# Altium schematic sheet ATMega2560 and USB.SchDoc
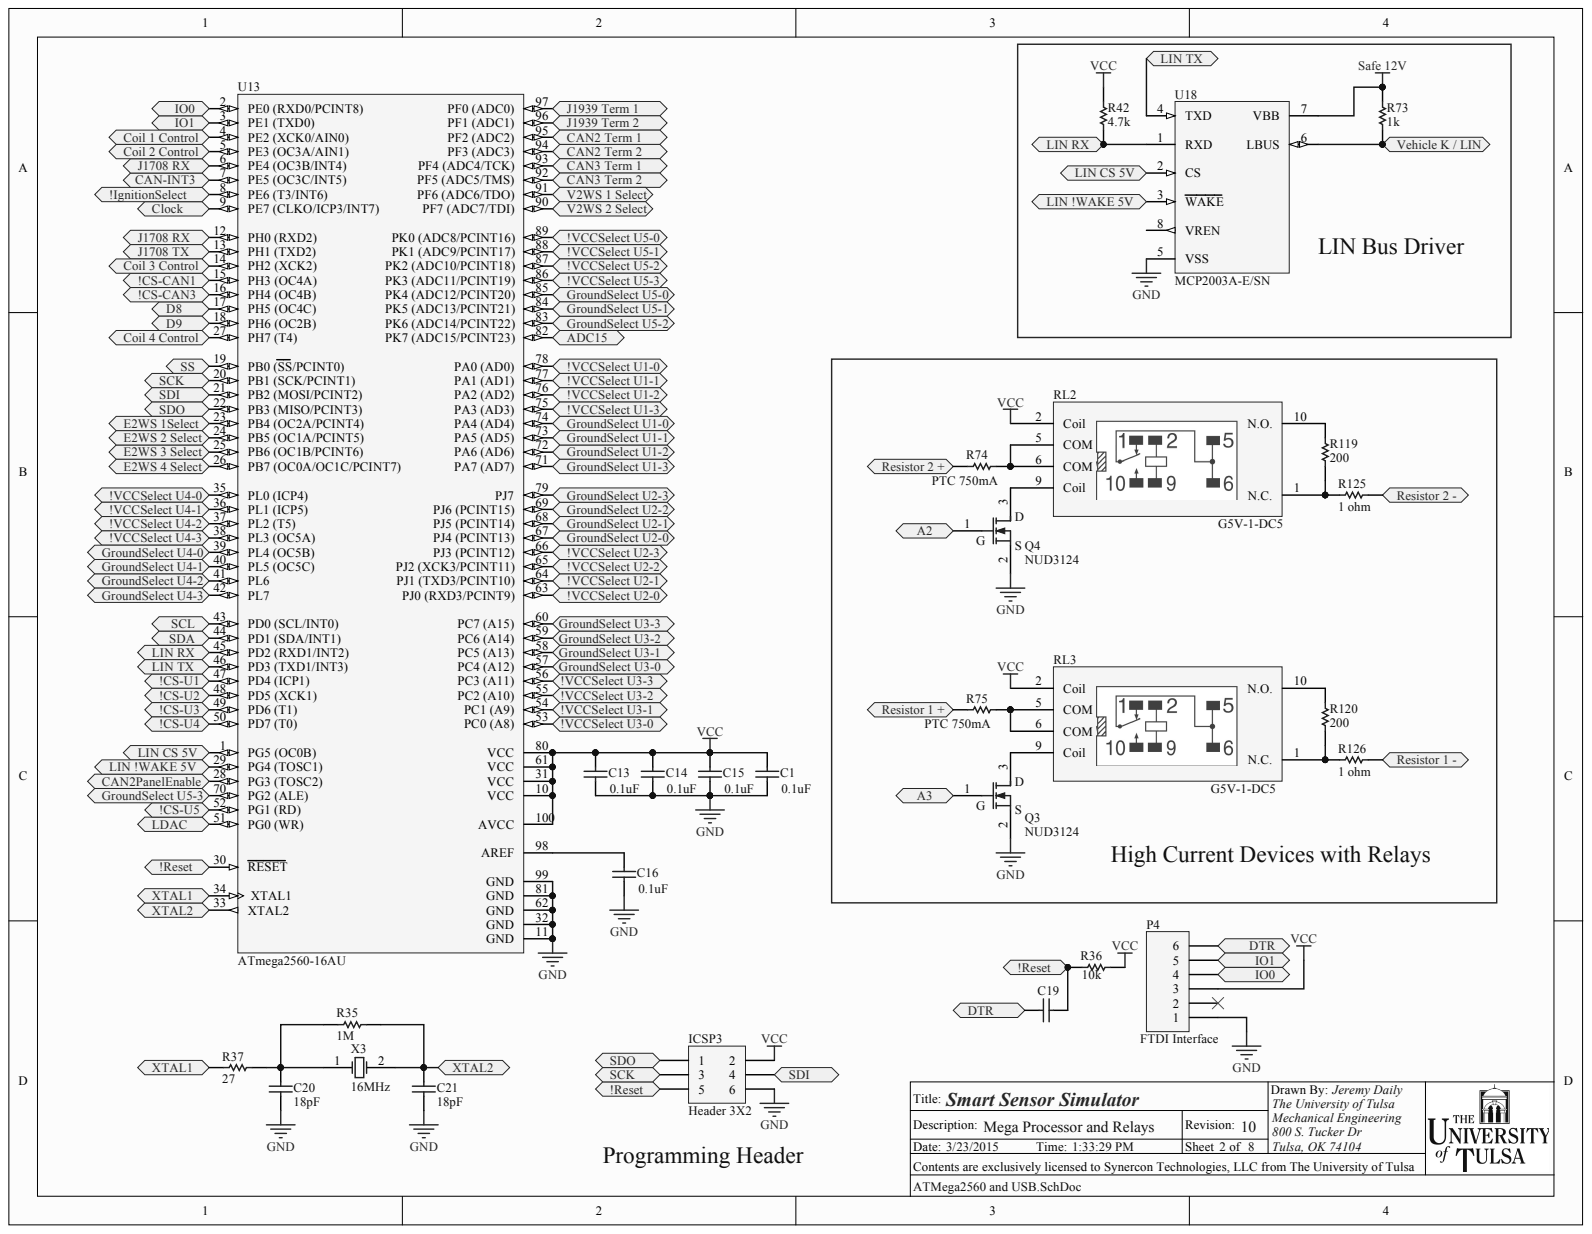
[diagram p0: ATMega2560 and USB.SchDoc]

## Parts
C1  0.1uF  [0603]
C13  0.1uF  [0603]
C14  0.1uF  [0603]
C15  0.1uF  [0603]
C16  0.1uF  [0603]
C19  0.1uF  [0603]  (value not drawn)
C20  18pF  [0603]
C21  18pF  [0603]
ICSP3  Header 3X2  [ICSP Header (2x3)]
P4  FTDI Interface  [FTDi Header]
Q3  NUD3124  [SOT-23-3]
Q4  NUD3124  [SOT-23-3]
R35  1M  [0603]
R36  10k  [0805]
R37  27  [0805]
R42  4.7k  [0603]
R73  1k  [0603]
R74  PTC 750mA  [1206]
R75  PTC 750mA  [1206]
R119  200  [0805]
R120  200  [0805]
R125  1 ohm  [NTC 7.5mm]
R126  1 ohm  [NTC 7.5mm]
RL2  G5V-1-DC5  [OMRON G5V Relay]
RL3  G5V-1-DC5  [OMRON G5V Relay]
U13  ATmega2560-16AU  [100A_L]
U18  MCP2003A-E/SN  [SOIC-SN8_N]
X3  16MHz  [XTAL 2 pad]

## Ports
!CS-CAN1, !CS-CAN3, !CS-U1, !CS-U2, !CS-U3, !CS-U4, !CS-U5, !IGNITIONSELECT, !RESET, !VCCSELECT U1-0, !VCCSELECT U1-1, !VCCSELECT U1-2, !VCCSELECT U1-3, !VCCSELECT U2-0, !VCCSELECT U2-1, !VCCSELECT U2-2, !VCCSELECT U2-3, !VCCSELECT U3-0, !VCCSELECT U3-1, !VCCSELECT U3-2, !VCCSELECT U3-3, !VCCSELECT U4-0, !VCCSELECT U4-1, !VCCSELECT U4-2, !VCCSELECT U4-3, !VCCSELECT U5-0, !VCCSELECT U5-1, !VCCSELECT U5-2, !VCCSELECT U5-3, A2, A3, ADC15, CAN2 TERM 1, CAN2 TERM 2, CAN2PANELENABLE, CAN3 TERM 1, CAN3 TERM 2, CAN-INT3, CLOCK, COIL 1 CONTROL, COIL 2 CONTROL, COIL 3 CONTROL, COIL 4 CONTROL, D8, D9, DTR, E2WS 1SELECT, E2WS 2 SELECT, E2WS 3 SELECT, E2WS 4 SELECT, GROUNDSELECT U1-0, GROUNDSELECT U1-1, GROUNDSELECT U1-2, GROUNDSELECT U1-3, GROUNDSELECT U2-0, GROUNDSELECT U2-1, GROUNDSELECT U2-2, GROUNDSELECT U2-3, GROUNDSELECT U3-0, GROUNDSELECT U3-1, GROUNDSELECT U3-2, GROUNDSELECT U3-3, GROUNDSELECT U4-0, GROUNDSELECT U4-1, GROUNDSELECT U4-2, GROUNDSELECT U4-3, GROUNDSELECT U5-0, GROUNDSELECT U5-1, GROUNDSELECT U5-2, GROUNDSELECT U5-3, IO0, IO1, J1708 RX, J1708 TX, J1939 TERM 1, J1939 TERM 2, LDAC, LIN !WAKE 5V, LIN CS 5V, LIN RX, LIN TX, RESISTOR 1 +, RESISTOR 1 -, RESISTOR 2 +, RESISTOR 2 -, SCK, SCL, SDA, SDI, SDO, SS, V2WS 1 SELECT, V2WS 2 SELECT, VEHICLE K / LIN, XTAL1, XTAL2

## Nets
GND: C1.2 C13.2 C14.2 C15.2 C16.2 C20.1 C21.1 ICSP3.6 P4.1 Q3.2 Q4.2 U13.11(GND) U13.32(GND) U13.62(GND) U13.81(GND) U13.99(GND) U18.5(VSS)
SAFE 12V: R73.1 U18.7(VBB)
VCC: C1.1 C13.1 C14.1 C15.1 ICSP3.2 P4.3 R36.1 R42.2 RL2.2(Coil) RL3.2(Coil) U13.10(VCC) U13.31(VCC) U13.61(VCC) U13.80(VCC) U13.100(AVCC)
!CS-CAN1: U13.15(PH3_(OC4A)) ; port !CS-CAN1
!CS-CAN3: U13.16(PH4_(OC4B)) ; port !CS-CAN3
!CS-U1: U13.47(PD4_(ICP1)) ; port !CS-U1
!CS-U2: U13.48(PD5_(XCK1)) ; port !CS-U2
!CS-U3: U13.49(PD6_(T1)) ; port !CS-U3
!CS-U4: U13.50(PD7_(T0)) ; port !CS-U4
!CS-U5: U13.52(PG1_(RD)) ; port !CS-U5
A2: Q4.1 ; port A2
A3: Q3.1 ; port A3
ADC15: U13.82(PK7_(ADC15/PCINT23)) ; port ADC15
CAN-INT3: U13.7(PE5_(OC3C/INT5)) ; port CAN-INT3
D8: U13.17(PH5_(OC4C)) ; port D8
D9: U13.18(PH6_(OC2B)) ; port D9
DTR: C19.2 P4.6 ; port DTR
IO0: P4.4 U13.2(PE0_(RXD0/PCINT8)) ; port IO0
IO1: P4.5 U13.3(PE1_(TXD0)) ; port IO1
J1708 RX: U13.6(PE4_(OC3B/INT4)) U13.12(PH0_(RXD2)) ; port J1708 RX
J1708 TX: U13.13(PH1_(TXD2)) ; port J1708 TX
LDAC: U13.51(PG0_(WR)) ; port LDAC
LIN !WAKE 5V: U13.29(PG4_(TOSC1)) U18.3(WAKE) ; port LIN !WAKE 5V
LIN CS 5V: U13.1(PG5_(OC0B)) U18.2(CS) ; port LIN CS 5V
LIN RX: R42.1 U13.45(PD2_(RXD1/INT2)) U18.1(RXD) ; port LIN RX
LIN TX: U13.46(PD3_(TXD1/INT3)) U18.4(TXD) ; port LIN TX
SCK: ICSP3.3 U13.20(PB1_(SCK/PCINT1)) ; port SCK
SCL: U13.43(PD0_(SCL/INT0)) ; port SCL
SDA: U13.44(PD1_(SDA/INT1)) ; port SDA
SDI: ICSP3.4 U13.21(PB2_(MOSI/PCINT2)) ; port SDI
SDO: ICSP3.1 U13.22(PB3_(MISO/PCINT3)) ; port SDO
SS: U13.19(PB0_(SS/PCINT0)) ; port SS
XTAL1: R37.2 U13.34(XTAL1) ; port XTAL1
XTAL2: C21.2 R35.1 U13.33(XTAL2) X3.2 ; port XTAL2
NetC16_1: C16.1 U13.98(AREF)
NetC19_1: C19.1 ICSP3.5 R36.2 U13.30(RESET) ; port !RESET
NetC20_2: C20.2 R35.2 R37.1 X3.1
NetQ3_3: Q3.3 RL3.9(Coil)
NetQ4_3: Q4.3 RL2.9(Coil)
NetR73_2: R73.2 U18.6(LBUS) ; port VEHICLE K / LIN
NetR74_1: R74.1
NetR74_2: R74.2 RL2.5(COM) RL2.6(COM)
NetR75_1: R75.1
NetR75_2: R75.2 RL3.5(COM) RL3.6(COM)
NetR119_1: R119.1 RL2.10(N.O.)
NetR119_2: R119.2 R125.1 RL2.1(N.C.)
NetR120_1: R120.1 RL3.10(N.O.)
NetR120_2: R120.2 R126.1 RL3.1(N.C.)
NetR125_2: R125.2
NetR126_2: R126.2
NetU13_4: U13.4(PE2_(XCK0/AIN0)) ; port COIL 1 CONTROL
NetU13_5: U13.5(PE3_(OC3A/AIN1)) ; port COIL 2 CONTROL
NetU13_8: U13.8(PE6_(T3/INT6)) ; port !IGNITIONSELECT
NetU13_9: U13.9(PE7_(CLKO/ICP3/INT7)) ; port CLOCK
NetU13_14: U13.14(PH2_(XCK2)) ; port COIL 3 CONTROL
NetU13_23: U13.23(PB4_(OC2A/PCINT4)) ; port E2WS 1SELECT
NetU13_24: U13.24(PB5_(OC1A/PCINT5)) ; port E2WS 2 SELECT
NetU13_25: U13.25(PB6_(OC1B/PCINT6)) ; port E2WS 3 SELECT
NetU13_26: U13.26(PB7_(OC0A/OC1C/PCINT7)) ; port E2WS 4 SELECT
NetU13_27: U13.27(PH7_(T4)) ; port COIL 4 CONTROL
NetU13_28: U13.28(PG3_(TOSC2)) ; port CAN2PANELENABLE
NetU13_35: U13.35(PL0_(ICP4)) ; port !VCCSELECT U4-0
NetU13_36: U13.36(PL1_(ICP5)) ; port !VCCSELECT U4-1
NetU13_37: U13.37(PL2_(T5)) ; port !VCCSELECT U4-2
NetU13_38: U13.38(PL3_(OC5A)) ; port !VCCSELECT U4-3
NetU13_39: U13.39(PL4_(OC5B)) ; port GROUNDSELECT U4-0
NetU13_40: U13.40(PL5_(OC5C)) ; port GROUNDSELECT U4-1
NetU13_41: U13.41(PL6) ; port GROUNDSELECT U4-2
NetU13_42: U13.42(PL7) ; port GROUNDSELECT U4-3
NetU13_53: U13.53(PC0_(A8)) ; port !VCCSELECT U3-0
NetU13_54: U13.54(PC1_(A9)) ; port !VCCSELECT U3-1
NetU13_55: U13.55(PC2_(A10)) ; port !VCCSELECT U3-2
NetU13_56: U13.56(PC3_(A11)) ; port !VCCSELECT U3-3
NetU13_57: U13.57(PC4_(A12)) ; port GROUNDSELECT U3-0
NetU13_58: U13.58(PC5_(A13)) ; port GROUNDSELECT U3-1
NetU13_59: U13.59(PC6_(A14)) ; port GROUNDSELECT U3-2
NetU13_60: U13.60(PC7_(A15)) ; port GROUNDSELECT U3-3
NetU13_63: U13.63(PJ0_(RXD3/PCINT9)) ; port !VCCSELECT U2-0
NetU13_64: U13.64(PJ1_(TXD3/PCINT10)) ; port !VCCSELECT U2-1
NetU13_65: U13.65(PJ2_(XCK3/PCINT11)) ; port !VCCSELECT U2-2
NetU13_66: U13.66(PJ3_(PCINT12)) ; port !VCCSELECT U2-3
NetU13_67: U13.67(PJ4_(PCINT13)) ; port GROUNDSELECT U2-0
NetU13_68: U13.68(PJ5_(PCINT14)) ; port GROUNDSELECT U2-1
NetU13_69: U13.69(PJ6_(PCINT15)) ; port GROUNDSELECT U2-2
NetU13_70: U13.70(PG2_(ALE)) ; port GROUNDSELECT U5-3
NetU13_71: U13.71(PA7_(AD7)) ; port GROUNDSELECT U1-3
NetU13_72: U13.72(PA6_(AD6)) ; port GROUNDSELECT U1-2
NetU13_73: U13.73(PA5_(AD5)) ; port GROUNDSELECT U1-1
NetU13_74: U13.74(PA4_(AD4)) ; port GROUNDSELECT U1-0
NetU13_75: U13.75(PA3_(AD3)) ; port !VCCSELECT U1-3
NetU13_76: U13.76(PA2_(AD2)) ; port !VCCSELECT U1-2
NetU13_77: U13.77(PA1_(AD1)) ; port !VCCSELECT U1-1
NetU13_78: U13.78(PA0_(AD0)) ; port !VCCSELECT U1-0
NetU13_79: U13.79(PJ7) ; port GROUNDSELECT U2-3
NetU13_83: U13.83(PK6_(ADC14/PCINT22)) ; port GROUNDSELECT U5-2
NetU13_84: U13.84(PK5_(ADC13/PCINT21)) ; port GROUNDSELECT U5-1
NetU13_85: U13.85(PK4_(ADC12/PCINT20)) ; port GROUNDSELECT U5-0
NetU13_86: U13.86(PK3_(ADC11/PCINT19)) ; port !VCCSELECT U5-3
NetU13_87: U13.87(PK2_(ADC10/PCINT18)) ; port !VCCSELECT U5-2
NetU13_88: U13.88(PK1_(ADC9/PCINT17)) ; port !VCCSELECT U5-1
NetU13_89: U13.89(PK0_(ADC8/PCINT16)) ; port !VCCSELECT U5-0
NetU13_90: U13.90(PF7_(ADC7/TDI)) ; port V2WS 2 SELECT
NetU13_91: U13.91(PF6_(ADC6/TDO)) ; port V2WS 1 SELECT
NetU13_92: U13.92(PF5_(ADC5/TMS)) ; port CAN3 TERM 2
NetU13_93: U13.93(PF4_(ADC4/TCK)) ; port CAN3 TERM 1
NetU13_94: U13.94(PF3_(ADC3)) ; port CAN2 TERM 2
NetU13_95: U13.95(PF2_(ADC2)) ; port CAN2 TERM 1
NetU13_96: U13.96(PF1_(ADC1)) ; port J1939 TERM 2
NetU13_97: U13.97(PF0_(ADC0)) ; port J1939 TERM 1

Not connected: P4 2; U18 8(VREN)

## Library data of the parts
C1 C13-C16 C19 = Cap: CAP CER 0.1UF 50V 10% X7R 0603; Manufacturer: Murata Electronics North America; PartNumber: GRM188R71H104KA93D; Component Kind: Standard; Library Name: SSS Schematic Library.SchLib; Signal Integrity: Cap; Simulation: CAP; Supplier 1: Digi-Key; Supplier Part Number 1: 490-1519-1-ND
C20 C21 = Cap: CAP CER 18PF 50V 5% NP0 0603; Manufacturer: Kemet; PartNumber: C0603C180J5GACTU; Component Kind: Standard; Library Name: SSS Schematic Library.SchLib; Signal Integrity: Cap; Simulation: CAP; Supplier 1: Digi-Key; Supplier Part Number 1: 399-1052-1-ND
ICSP3 = Header 3X2: CONN HEADER 6POS DUAL .100 TIN; Manufacturer: 3M; PartNumber: 929836-01-03-RK; Component Kind: Standard; Library Name: Miscellaneous Connectors.IntLib; Signal Integrity: Connector; Supplier 1: Digi-Key; Supplier Part Number 1: 929836E-01-03-ND
P4 = Header 6: Header, 6-Pin; Component Kind: Standard; Library Name: Miscellaneous Connectors.IntLib; Signal Integrity: Connector
Q3 Q4 = IRLML6344TRPbF: IC INDCT LOAD DRVR AUTO SOT23; Manufacturer: ON Semiconductor; PartNumber: NUD3124LT1G; Component Kind: Standard; Library Name: SSS Schematic Library.SchLib; Supplier 1: Digi-Key; Supplier Part Number 1: NUD3124LT1GOSCT-ND
R35 = Chip Resistor: RES SMD 1M OHM 1% 1/10W 0603; Manufacturer: Panasonic Electronic Components; PartNumber: ERJ-3EKF1004V; Component Kind: Standard; Library Name: SSS Schematic Library.SchLib; Supplier 1: Digi-Key; Supplier Part Number 1: P1.00MHCT-ND
R36 = Chip Resistor: RES SMD 10K OHM 1% 1/8W 0805; Manufacturer: Panasonic Electronic Components; PartNumber: ERJ-6ENF1002V; Component Kind: Standard; Library Name: SSS Schematic Library.SchLib; Supplier 1: Digi-Key; Supplier Part Number 1: P10.0KCCT-ND
R37 = Chip Resistor: RES SMD 27 OHM 1% 1/8W 0805; Manufacturer: Panasonic Electronic Components; PartNumber: ERJ-6ENF27R0V; Component Kind: Standard; Library Name: SSS Schematic Library.SchLib; Supplier 1: Digi-Key; Supplier Part Number 1: P27.0CCT-ND
R42 = Chip Resistor: RES SMD 4.7K OHM 1% 1/8W 0805; Manufacturer: Panasonic Electronic Components; PartNumber: ERJ-6ENF4701V; Component Kind: Standard; Supplier 1: Digi-Key; Supplier Part Number 1: P4.70KCCT-ND; Library Name: Daily_Integrated_Library.IntLib
R73 = Chip Resistor: RES SMD 1K OHM 1% 1/10W 0603; Manufacturer: Panasonic Electronic Components; PartNumber: ERJ-3EKF1001V; Component Kind: Standard; Library Name: SSS Schematic Library.SchLib; Supplier 1: Digi-Key; Supplier Part Number 1: P1.00KHCT-ND
R74 R75 = SMD Resistor: PTC RESTTBLE 0.75A 16V CHIP 1206; Manufacturer: Bel Fuse Inc; PartNumber: 0ZCJ0075AF2E; Component Kind: Standard; Library Name: SSS Schematic Library.SchLib; Supplier 1: Digi-Key; Supplier Part Number 1: 507-1805-1-ND
R119 R120 = Chip Resistor: RES SMD 200 OHM 1% 1/2W 0805; Manufacturer: Panasonic Electronic Components; PartNumber: ERJ-P06F2000V; Component Kind: Standard; Library Name: SSS Schematic Library.SchLib; Supplier 1: Digi-Key; Supplier Part Number 1: P16064CT-ND
R125 R126 = Chip Resistor: RES 1 OHM 5W 10% AXIAL; Manufacturer: Yageo; PartNumber: PNP300JR-73-1R; Component Kind: Standard; Library Name: SSS Schematic Library.SchLib; Supplier 1: Digi-Key; Supplier Part Number 1: 1.0AECT-ND
RL2 RL3 = Relay-G5V: OMRON RELAY GENERAL PURPOSE SPDT 1A 5V; Manufacturer: Omron Electronics Inc-EMC Div; Component Kind: Standard; Library Name: SSS Schematic Library.SchLib; PartNumber: G5V-1-DC5; Supplier 1: Digi-Key; Supplier Part Number 1: Z773-ND
U13 = ATmega2560-16AU: 8-bit AVR Microcontroller, 4.5-5.5V, 256KB Flash, 4KB EEPROM, 8KB SRAM, 86 GPIO pins, 100-pin TQFP, Industrial Grade (-40°C to 85°C), Pb-Free; Manufacturer: Atmel; Component Kind: Standard; ComponentLink2URL: http://www.atmel.com/dyn/resources/prod_documents/doc2549.pdf; ComponentLink3Description: ATmega640/1280/1281/2560/2561 Summary; ComponentLink3URL: http://www.atmel.com/dyn/resources/prod_documents/2549S.pdf; ComponentLink4Description: Atmel.com Home; ComponentLink4URL: http://www.atmel.com; DatasheetVersion: revL, Aug-2007; Library Name: Atmel Microcontroller megaAVR.IntLib; PackageDescription: 100-Pin Thin Profile Plastic Quad Flat Pack (TQFP), 14 x 14 x 1.0 mm Body, 0.5 mm Pitch; PackageVersion: revC, Oct-2001; PartNumber: ATMEGA2560-16AU; Supplier 1: Digi-Key; Supplier 2: Farnell; Supplier 3: Farnell; Supplier 4: Mouser; Supplier Part Number 1: ATMEGA2560-16AU-ND; Supplier Part Number 2: 1288330; Supplier Part Number 3: 1661740; Supplier Part Number 4: 556-ATMEGA2560-16AU
U18 = MCP2003AT-E/SN: LIN J2602 Transceiver, 8-Pin SOIC, Extended Temperature, Tape and Reel; Manufacturer: Microchip; Code_IPC: SOIC127P600-8; Component Kind: Standard; ComponentLink1Description: Manufacturer URL; ComponentLink2URL: http://ww1.microchip.com/downloads/en/DeviceDoc/22230D.pdf; ComponentLink3Description: Package Specification; ComponentLink3URL: http://www.microchip.com/stellent/groups/techpub_sg/documents/packagingspec/en012702.pdf; DatasheetVersion: revD, Dec-2011; Library Name: Microchip Interface.IntLib; Mounting Technology: Surface Mount; PackageDescription: 8-Lead Plastic Small Outline (SN) - Narrow, 3.90mm Body [SOIC]; PackageVersion: revBB, Aug-2009; PartNumber: MCP2003A-E/SN; Supplier 1: Digi-Key; Supplier 2: Mouser; Supplier Part Number 1: MCP2003A-E/SN-ND; Supplier Part Number 2: 579-MCP2003ATESN
X3 = Crystal Oscillator: CRYSTAL 16MHZ 18PF SMD; Manufacturer: TXC CORPORATION; PartNumber: 7A-16.000MAAJ-T; Component Kind: Standard; Library Name: SSS Schematic Library.SchLib; Supplier 1: Digi-Key; Supplier Part Number 1: 887-1086-1-ND
